# Altium schematic sheet SeeeduinoLoRaWAN.SchDoc(SeeeduinoLoRaWAN)
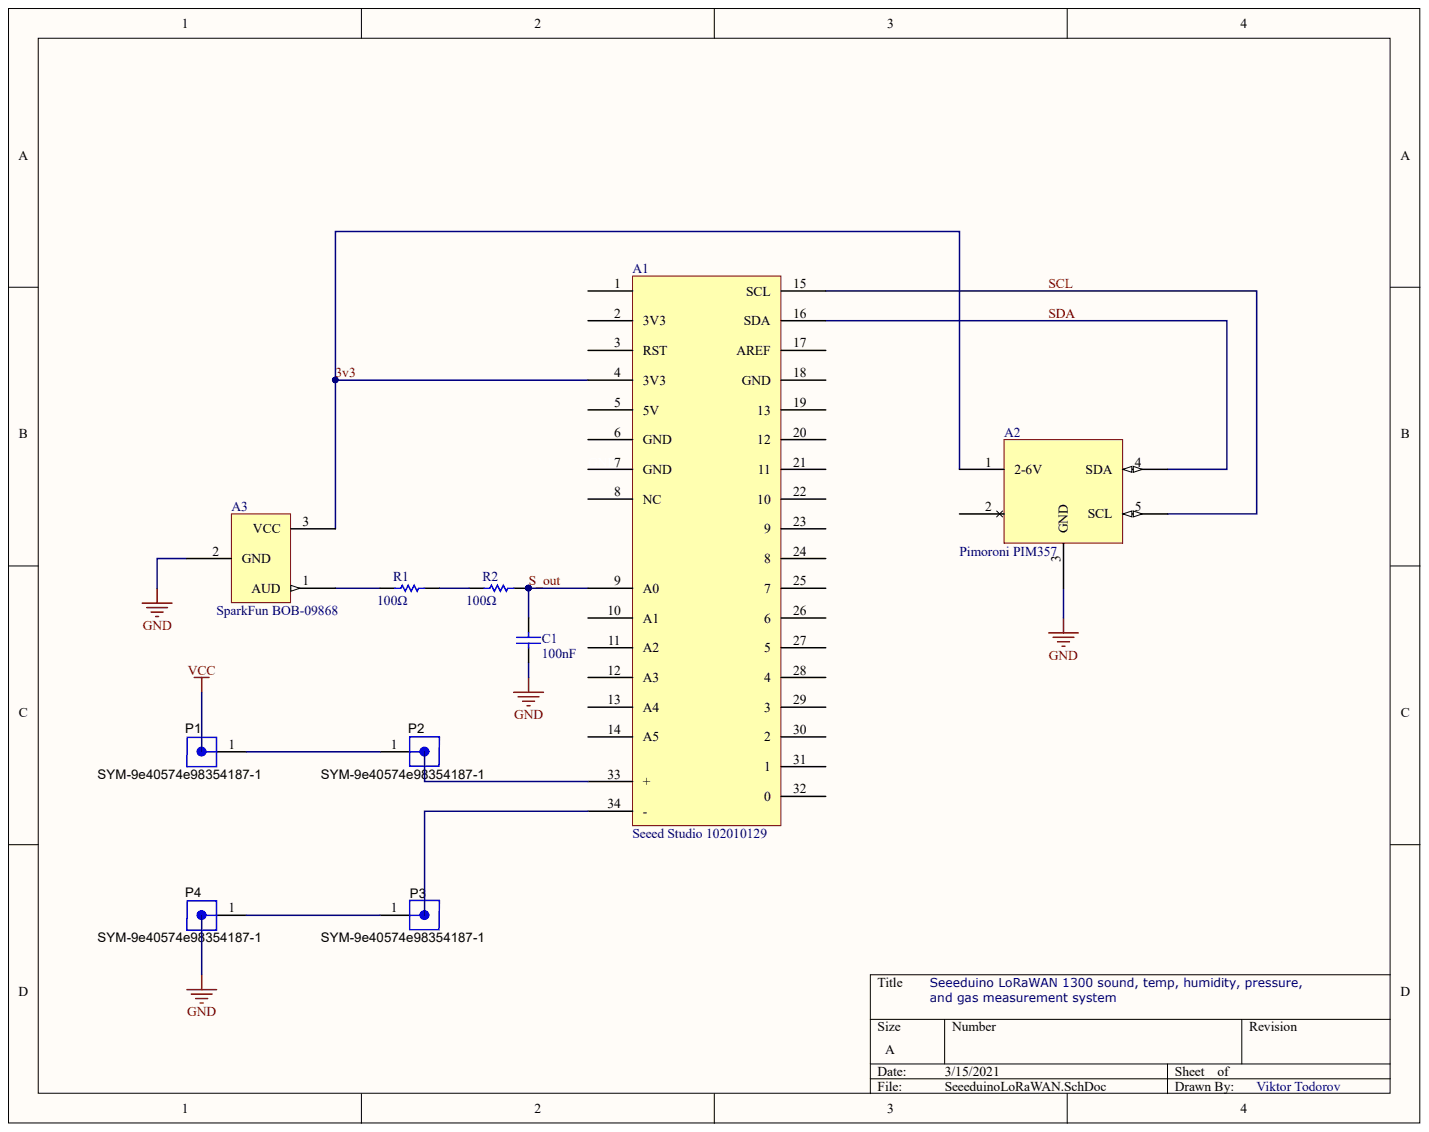
[diagram p0: SeeeduinoLoRaWAN.SchDoc]

## Parts
A1  Seeed Studio 102010129  Manufacturer Part Number: 102010129  [PCB-srpp1j3awc3jj7n5pbew-1]
A2  Pimoroni PIM357  Manufacturer Part Number: PIM357  [PCB-qvs4aq9kb5po7iowkm1p-1]
A3  SparkFun BOB-09868  Manufacturer Part Number: BOB-09868  [PCB-1potsi048ebqysjrldfj-1]
C1  100nF  [PCB-sa3w44oy0wih14a9m5c9-1]
P1  SYM-9e40574e98354187-1  [PCB-lm1opiol0yx140ggi4xe-1]
P2  SYM-9e40574e98354187-1  [PCB-lm1opiol0yx140ggi4xe-1]
P3  SYM-9e40574e98354187-1  [PCB-lm1opiol0yx140ggi4xe-1]
P4  SYM-9e40574e98354187-1  [PCB-lm1opiol0yx140ggi4xe-1]
R1  value 100Ω  [PCB-uegyx4izrxx2o2dg06ir-1]  (hidden comment: TE Connectivity / AMP 1622297-1)
R2  value 100Ω  [PCB-uegyx4izrxx2o2dg06ir-1]  (hidden comment: TE Connectivity / AMP 1622297-1)

## Nets
3V3: A1.4(3V3) A2.1(2-6V) A3.3
GND: A1.7(GND) A2.3(GND) A3.2 C1.1
S_OUT: A1.9(A0) C1.2 R2.2
SCL: A1.15(SCL) A2.5(SCL)
SDA: A1.16(SDA) A2.4(SDA)
NetA3_1: A3.1 R1.1
NetP1_1: P1.1 P2.1
NetP3_1: P3.1 P4.1
NetR1_2: R1.2 R2.1
VCC:

Not connected: A1 1 2(3V3) 3(RST) 5(5V) 6(GND) 8(NC) 10 11(A2) 12(A3) 13(A4) 14(A5) 17(AREF) 18(GND) 19 20 21 22 23 24 25 26 27 28 29 30 31 32 33(+) 34(-); A2 2

## Library data of the parts
A1 = CMP-af7562dcd17f70b2-7: RHF76-052 RF Transceiver Development Board; Component Kind: Standard; Library Name: FavoritesLibrary; OctopartID: af7562dcd17f70b2; Octopart URL: https://octopart.com/102010129-seeed+studio-77814636
A2 = CMP-dcca10411d86030d-10: Temperature Sensor, Bme680 Breakout Rohs Compliant: Yes; Component Kind: Standard; Library Name: FavoritesLibrary; OctopartID: dcca10411d86030d; Octopart URL: https://octopart.com/pim357-pimoroni-92398634
A3 = CMP-6b5aa3011b4bb49d-10: ADMP401 Mems Microphone; Component Kind: Standard; Library Name: FavoritesLibrary; OctopartID: 6b5aa3011b4bb49d; Octopart URL: https://octopart.com/bob-09868-sparkfun-22107511; Weight: 0.00 g
C1 = CMP-0012c9195eeddfd5-6: Cap Ceramic 0.1uF 50V X7R 10% Radial 5.08mm 125°C Bulk; Lead Length: 7.00 mm; Capacitance: 100 nF; Component Kind: Standard; Library Name: CommunityVault; Mounting Style: Through Hole; Packaging: Bulk; Size-Length: 5.08 mm
P1-P4 = CMP-df4d166140c6008a-2: 2.00mm (0.079") Pitch SIL Vertical 2.4mm PC Tail Pin Header, 3.5mm mating pin height, gold, 2 contacts; Component Kind: Standard; Library Name: CommunityVault; OctopartID: df4d166140c6008a; Octopart URL: http://octopart.com/m22-2510205-harwin-2538221
R1 R2 = CMP-165add3b387fc99d-2: Through Hole Thin Film Resistor, LR Series, 100 ohm, 600 mW, - 1%, 350 V, Axial Leaded; Component Kind: Standard; Library Name: CommunityVault; Lifecycle Status: Active; Mounting Style: Axial; Packaging: Ammo Pack; REACH SVHC Compliance: No SVHC; Resistance Tolerance: ±1%
